# Altium schematic sheet Hamilton.SchDoc(Hamilton)
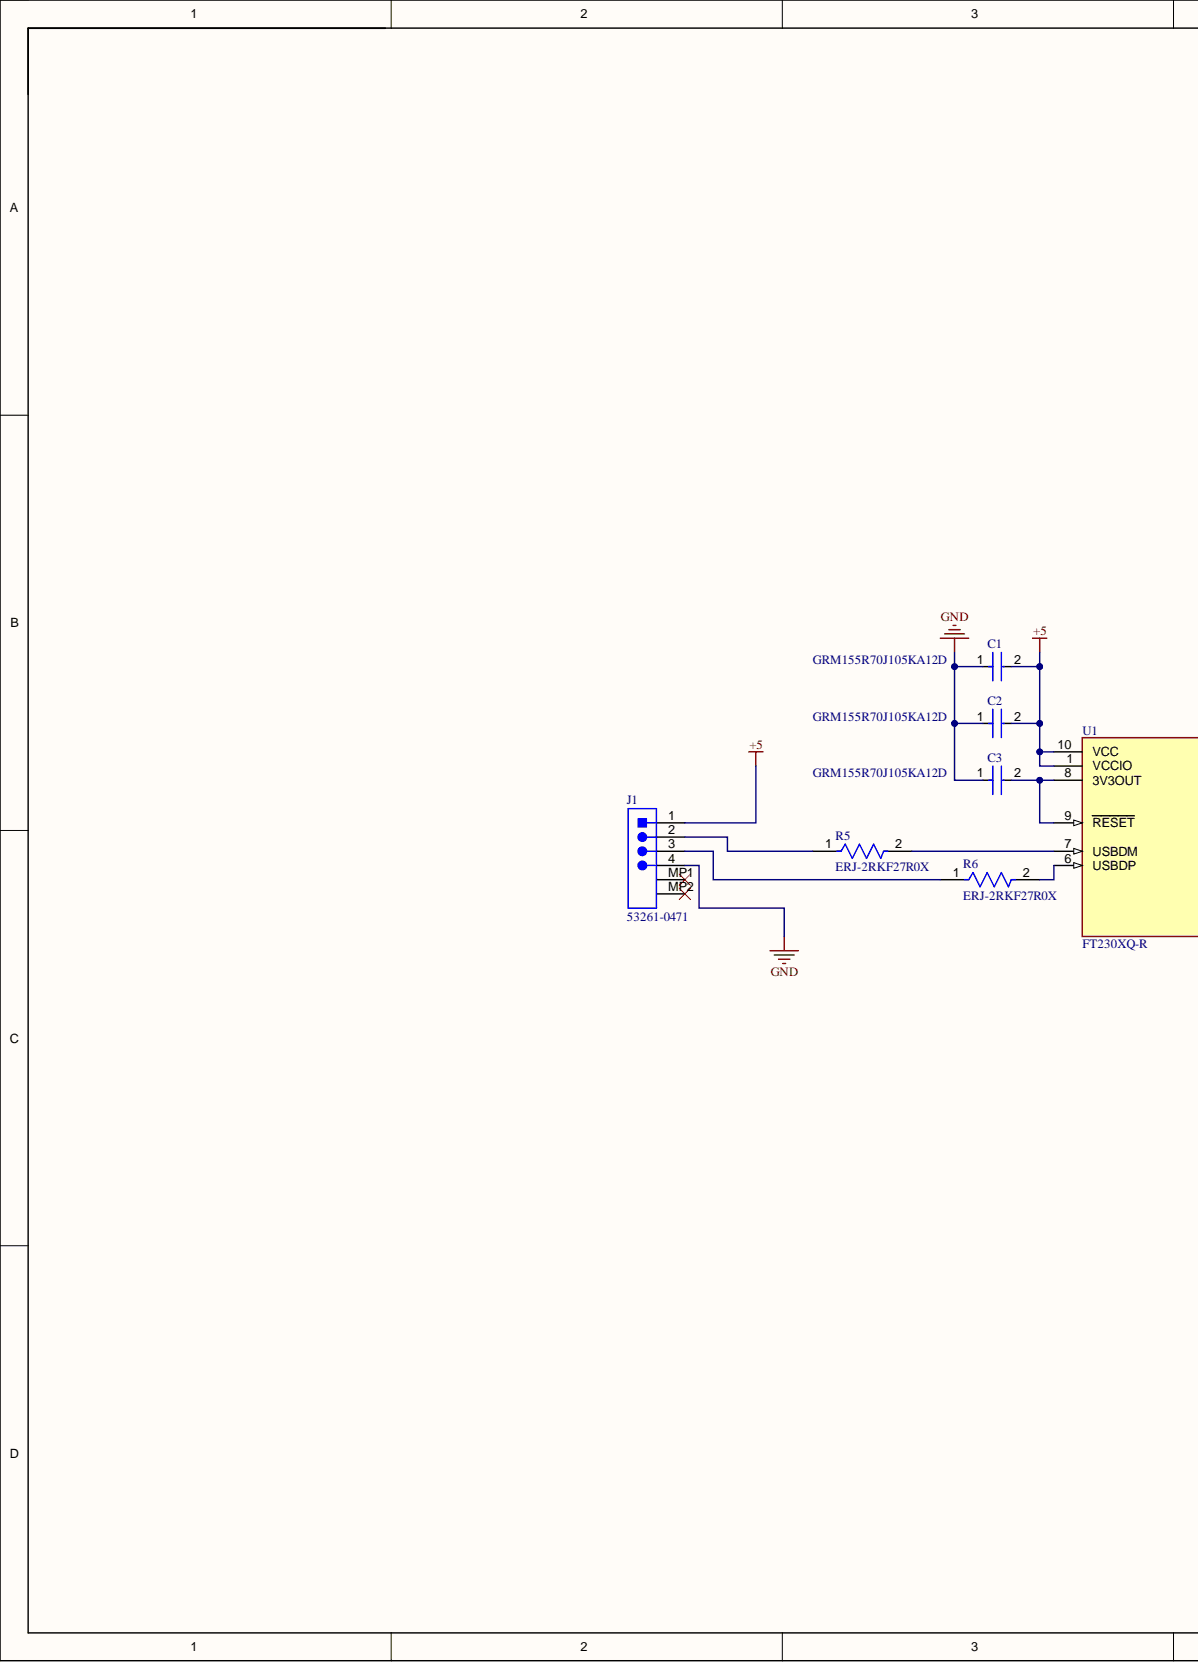
[diagram p0: Hamilton.SchDoc, left]
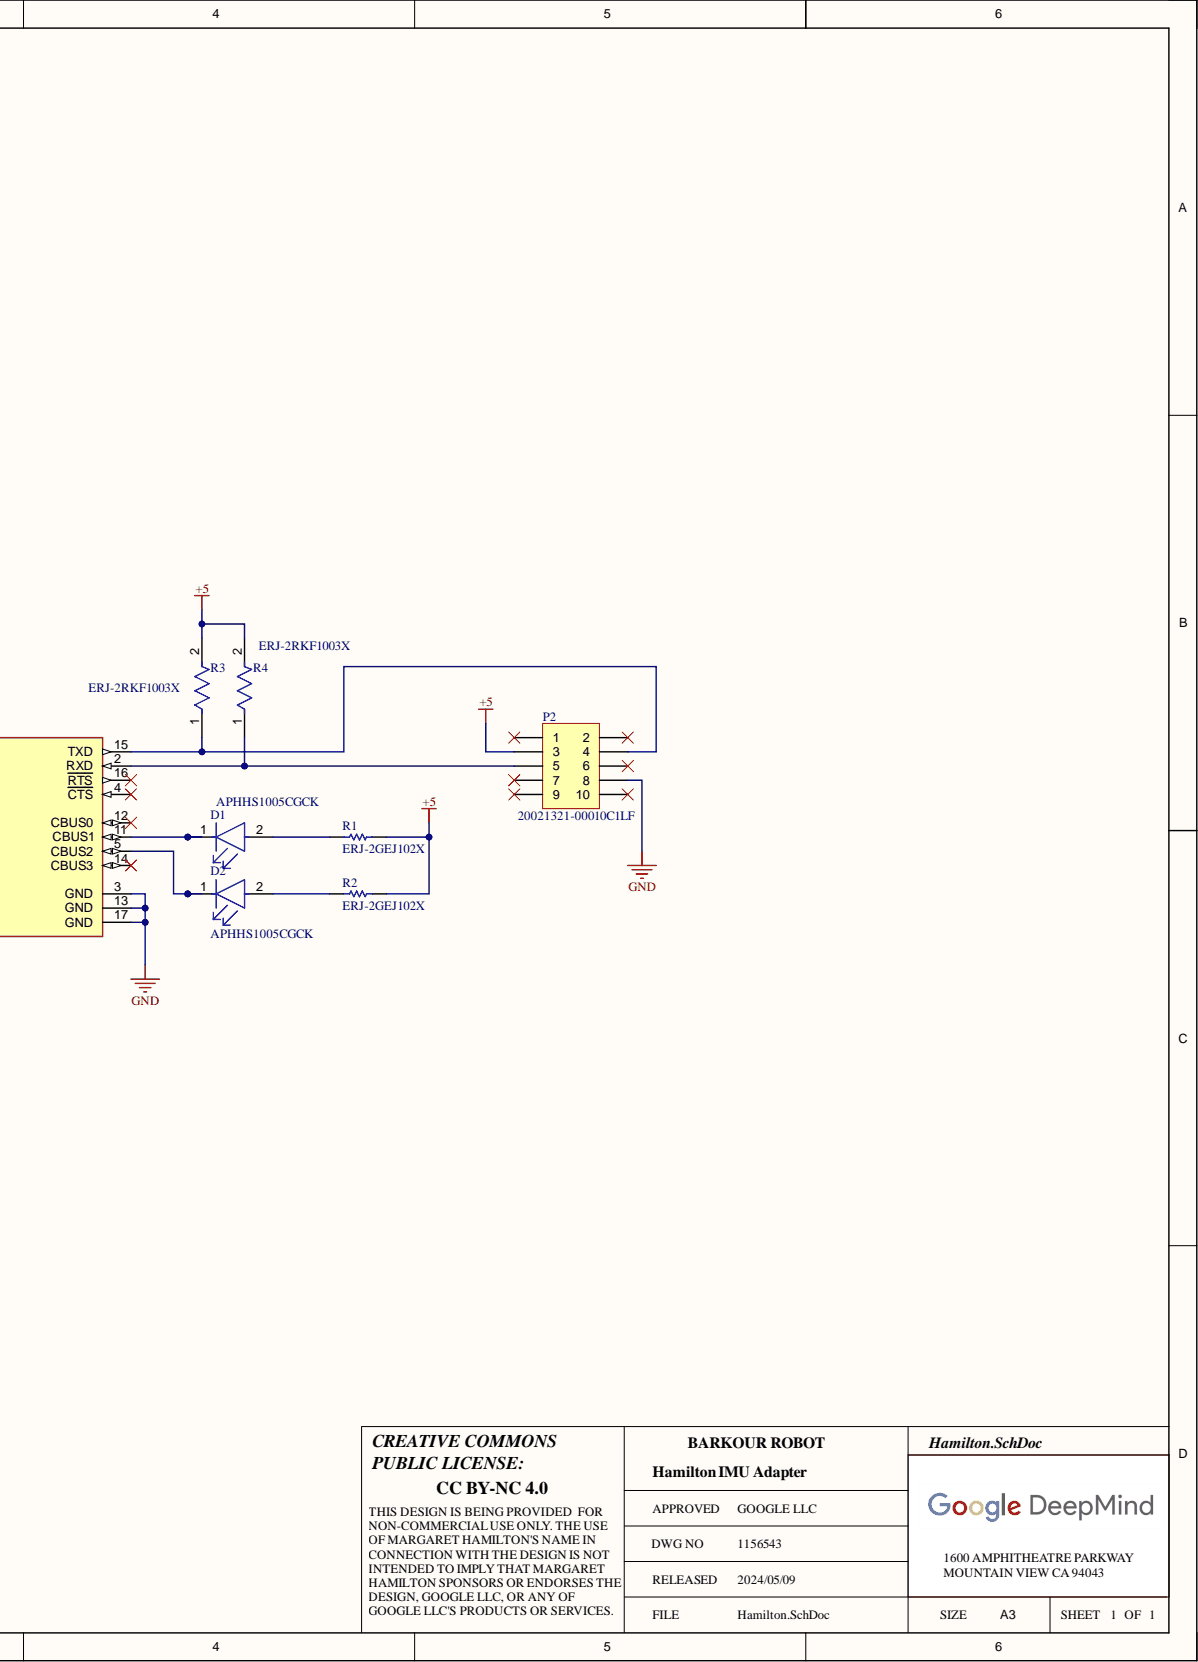
[diagram p1: Hamilton.SchDoc, right]

## Parts
C1  GRM155R70J105KA12D  [FP-GRM155-0_05-MFG]
C2  GRM155R70J105KA12D  [FP-GRM155-0_05-MFG]
C3  GRM155R70J105KA12D  [FP-GRM155-0_05-MFG]
D1  APHHS1005CGCK  [FP-APHHS1005CGCK-MFG]
D2  APHHS1005CGCK  [FP-APHHS1005CGCK-MFG]
J1  53261-0471  [MOLEX-53261-0471_V]
P2  20021321-00010C1LF  [PCBComponent_1]
R1  ERJ-2GEJ102X  [RESC1005X40X25NL05T05]
R2  ERJ-2GEJ102X  [RESC1005X40X25NL05T05]
R3  ERJ-2RKF1003X  [FP-ERJ2RK-IPC_C]
R4  ERJ-2RKF1003X  [FP-ERJ2RK-IPC_C]
R5  ERJ-2RKF27R0X  [FP-ERJ2R-IPC_B]
R6  ERJ-2RKF27R0X  [FP-ERJ2R-IPC_B]
U1  FT230XQ-R  [FP-QFN-16-MFG]

## Nets
+5: C1.2 C2.2 J1.1 P2.3 R1.2 R2.2 R3.2 R4.2 U1.1(VCCIO) U1.10(VCC)
GND: C1.1 C2.1 C3.1 J1.4 P2.8 U1.3(GND) U1.13(GND) U1.17(GND)
NetC3_2: C3.2 U1.8(3V3OUT) U1.9(RESET)
NetD1_1: D1.1 U1.11(CBUS1)
NetD1_2: D1.2 R1.1
NetD2_1: D2.1 U1.5(CBUS2)
NetD2_2: D2.2 R2.1
NetJ1_2: J1.2 R5.1
NetJ1_3: J1.3 R6.1
NetP2_4: P2.4 R3.1 U1.15(TXD)
NetP2_5: P2.5 R4.1 U1.2(RXD)
NetR5_2: R5.2 U1.7(USBDM)
NetR6_2: R6.2 U1.6(USBDP)

Not connected: J1 MP1 MP2; P2 1 2 6 7 9 10; U1 4(CTS) 12(CBUS0) 14(CBUS3) 16(RTS)

## Library data of the parts
C1-C3 = CMP-2008-04587-2: Chip Multilayer Ceramic Capacitors for General Purpose, 0402, 1.0uF, X7R, 15%, 10%, 6.3V; Capacitance: 1uF; Case Code (Imperial): 0402; Case Code (Metric): 1005; Case/Package: 0402; Component Kind: Standard; Depth: 0.5mm; Dielectric: X7R; Height: 0.5mm; Lead Free: Lead Free; Length: 1mm; Library Name: Altium Content Vault; Material: Ceramic; Max Operating Temperature: 125°C; Min Operating Temperature: -55°C; Mount: Surface Mount; Package Quantity: 10000; Packaging: Tape and Reel; Termination: SMD/SMT; Thickness: 0.022inch; Tolerance: 10%; Voltage Rating: 6.3V; Voltage Rating (DC): 6.3V; Weight: 0.000212oz; Width: 0.5mm
D1 D2 = CMP-44529-000044-1: LED GREEN CLEAR CHIP SMD; Dominant Wavelength: 570nm; Forward Current: 20mA; Forward Voltage: 2.1V; Luminous Intensity: 50mcd; Number of LEDs: 1; Viewing Angle: 120°; Case Code (Imperial): 0402; Case Code (Metric): 1005; Case/Package: 0402; Color: Green; Component Kind: Standard; Height: 0.6mm; Illumination Color: Green; Lead Free: Lead Free; Length: 1mm; Lens Color: Clear; Lens Style: Clear; Library Name: Altium Content Vault; Max Operating Temperature: 85°C; Min Operating Temperature: -40°C; Mount: Surface Mount; Number of Pins: 2; Package Quantity: 2000; Packaging: Tape and Reel; Test Current: 20mA; Wavelength: 570nm; Width: 0.5mm
J1 = CMP-2000-05238-1: Male Header, Pitch 1.25 mm, 1 x 4 Position, Height 3.4 mm, -40 to 85 degC, RoHS, Tape and Reel; Brand: Molex; Current Rating: 1A; Mounting Angle: Right; Number of Positions: 4; Pitch: 1.25mm; Voltage Rating: 125V; Component Kind: Standard; Contact Material: PhosphorBronze; Contact Plating: Tin; Datasheet URL: http://www.molex.com/pdm_docs/sd/532610471_sd.pdf; Datasheet Version: Rev. G, 01/2012; Factory Pack Quantity: 1000; Housing Material: Thermoplastic; Library Name: Altium Content Vault; Manufacturer: Molex; Manufacturer URL: http://www.molex.com/; Mounting Style: SMD/SMT; Number of Positions / Contacts: 4; Number of Rows: 1; Package Description: 1.25mm Pitch PicoBlade(TM) Header, Surface Mount, Right Angle, Lead-Free, 4 Circuits; Packaging: Reel; Product Category: Headers&WireHousings; Product Type: Headers; RoHS: Details; Series: 53261; Termination Style: SMD; Tradename: PicoBlade; Type: Shrouded
P2 = Header 5X2: Header, 5-Pin, Dual row; Component Kind: Standard; LatestRevisionDate: 17-Jul-2002; LatestRevisionNote: Re-released for DXP Platform.; Library Name: Miscellaneous Connectors.IntLib; Signal Integrity: Connector; Publisher: Altium Limited
R1 R2 = CMP-2002-00432-1: Manufacturer: Panasonic; Component Kind: Standard; Library Name: Altium Content Vault; Part Number: ERJ-2GEJ102X
R3 R4 = CMP-2002-00608-4: RES SMD 100K OHM 1% 1/10W 0402; Max Power Dissipation: 100mW; Resistance: 100kR; Temperature Coefficient: 100ppm/°C; Voltage Rating: 50V; Case Code (Imperial): 0402; Case Code (Metric): 1005; Case/Package: 0402; Component Kind: Standard; Composition: Thick Film; Contact Plating: Tin; Depth: 0.5mm; Features: Automotive AEC-Q200; Height: 0.35mm; Lead Free: Lead Free; Length: 1mm; Library Name: Altium Content Vault; Max Operating Temperature: 155°C; Military Standard: Not; Min Operating Temperature: -55°C; Mount: Surface Mount; Number of Pins: 2; Number of Terminations: 2; Package Quantity: 1; Packaging: Tape and Reel; Power Rating: 100mW; Radiation Hardening: No; REACH SVHC: No SVHC; Termination: SMD/SMT; Tolerance: 1%; Voltage Rating (DC): 50V; Width: 0.5mm
R5 R6 = CMP-2002-00830-2: RES SMD 27 OHM 1% 1/10W 0402; Max Power Dissipation: 100mW; Resistance: 27R; Temperature Coefficient: 100ppm/°C; Voltage Rating: 50V; Case Code (Imperial): 0402; Case Code (Metric): 1005; Case/Package: 0402; Component Kind: Standard; Construction: Rectangular; Contact Plating: Tin; Depth: 0.5mm; Features: Automotive AEC-Q200; Height: 0.35mm; Lead Free: Lead Free; Length: 1mm; Library Name: Altium Content Vault; Max Operating Temperature: 155°C; Military Standard: Not; Min Operating Temperature: -55°C; Mount: Surface Mount; Number of Pins: 2; Number of Terminations: 2; Package Quantity: 1; Packaging: Tape and Reel; Power Rating: 100mW; Radiation Hardening: No; REACH SVHC: No SVHC; Resistance Tolerance: 1%; Resistor Type: Thick Film; RoHS Compliant: Yes; Series: ERJ; Technology: THICK FILM; Termination: SMD/SMT; Tolerance: 1%; Voltage Rating (DC): 50V; Weight: 2.8E-05oz; Width: 0.5mm
U1 = CMP-0248-00010-3: IC USB SERIAL BASIC UART 16QFN; Data Rate: 12Mbps; Max Supply Voltage: 5.5V; Min Supply Voltage: 2.97V; Number of Channels: 1; Operating Supply Current: 8mA; Operating Supply Voltage: 5V; Case/Package: QFN; Component Kind: Standard; Height: 0.75mm; Interface: USB; Lead Free: Lead Free; Length: 4mm; Library Name: Altium Content Vault; Max Operating Temperature: 85°C; Min Operating Temperature: -40°C; Mount: Surface Mount; Number of Pins: 16; Package Quantity: 5000; Packaging: Tape and Reel; Weight: 0.002014oz; Width: 4mm
